# Altium schematic sheet CW341_PowerMeasure.SchDoc
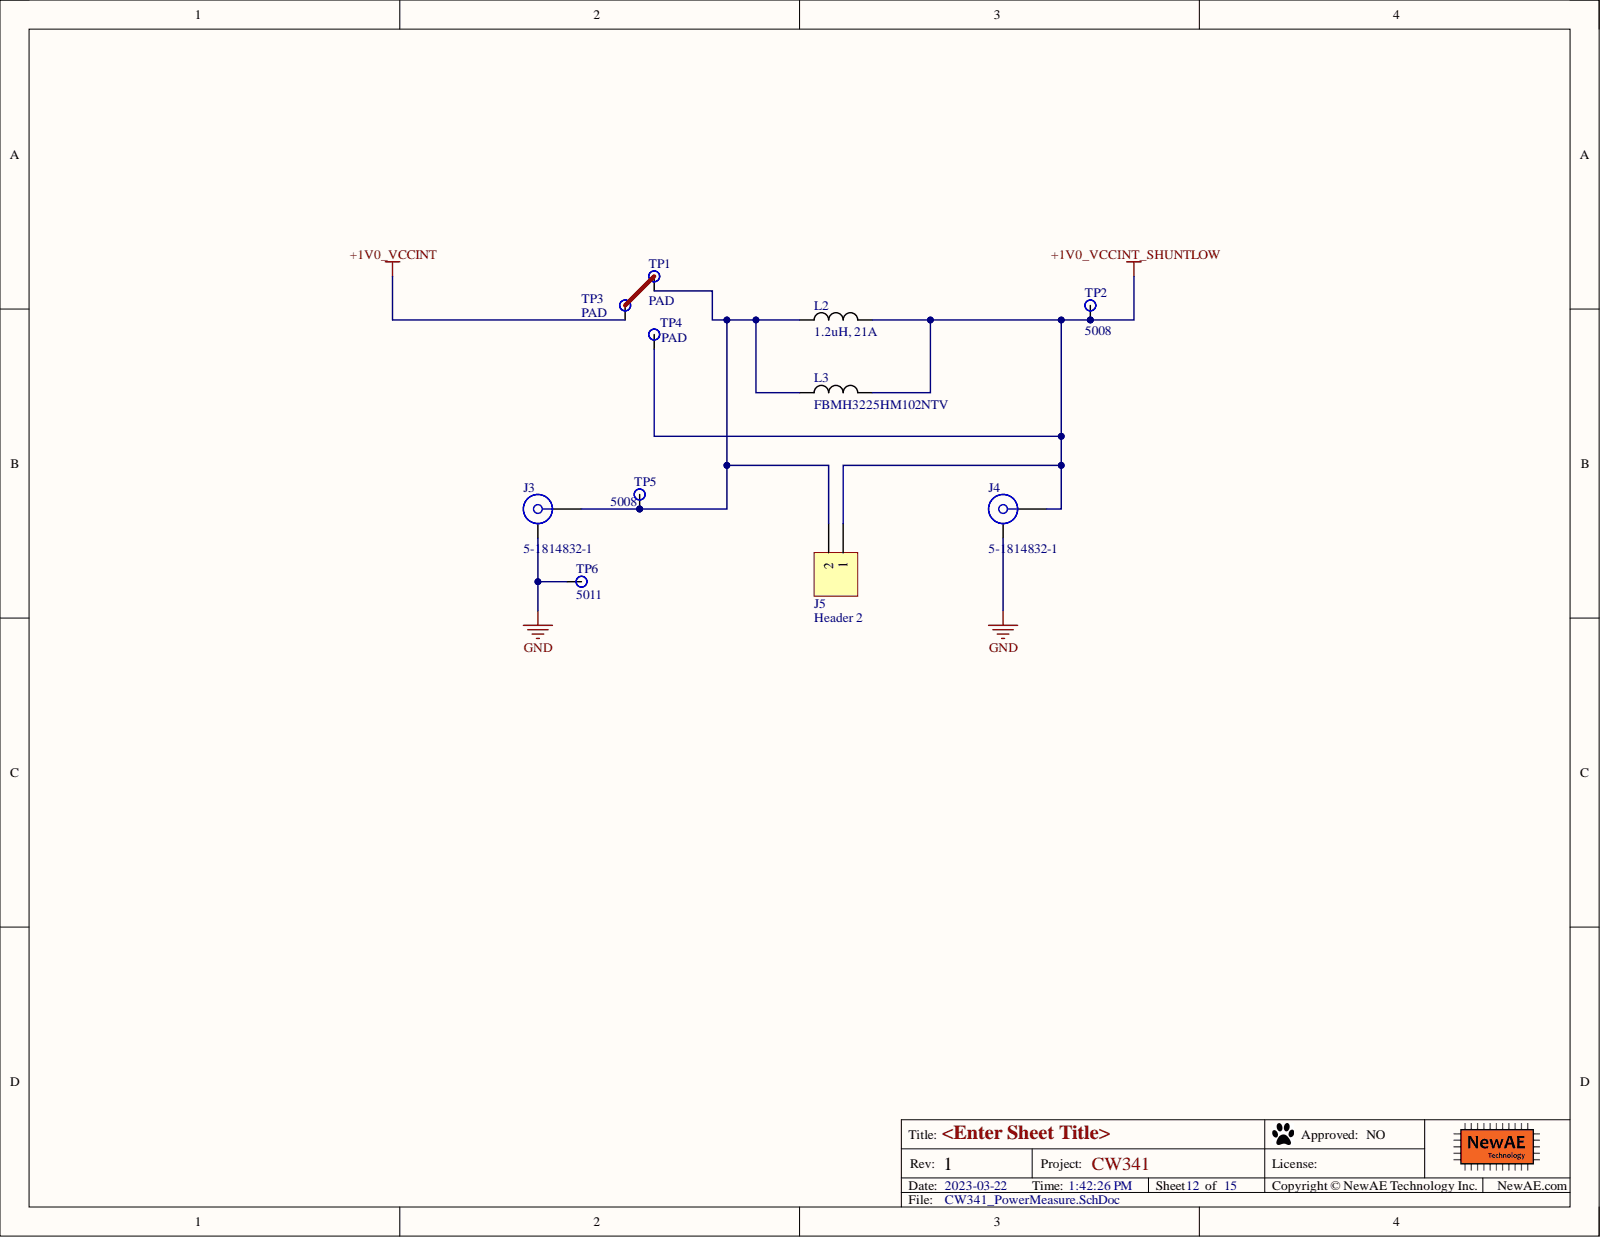
[diagram p0: CW341_PowerMeasure.SchDoc]

## Parts
J3  5-1814832-1  [SMA_VERT_1.65MMHOLE]
J4  5-1814832-1  [SMA_VERT_1.65MMHOLE]
J5  Header 2  [HDR1X2]
L2  1.2uH, 21A  [XAL7070]
L3  FBMH3225HM102NTV  [1210]
TP1  PAD  [9022-0-00-15-00-00-33-0]
TP2  5008  [KEYSTONE5008]
TP3  PAD  [9022-0-00-15-00-00-33-0]
TP4  PAD  [9022-0-00-15-00-00-33-0]
TP5  5008  [KEYSTONE5008]
TP6  5011  [KEYSTONE5011]

## Nets
+1V0_VCCINT: TP3.1
+1V0_VCCINT_SHUNTLOW: J4.1 J5.1 L2.2 L3.2 TP2.1 TP4.1
GND: J3.MH J4.MH TP6.1
NetJ3_1: J3.1 J5.2 L2.1 L3.1 TP1.1 TP5.1

## Library data of the parts
J3 J4 = 5-1814832-1: SMA, Vert; Manufacture 1: TE Connectivity; Component Group: Connector; Database Table Name: main; Component Kind: Standard; Component Type: Standard; Library Name: NewAE_AltiumLib.DBLib; Manufacture Part Number 1: 5-1814832-1; Part Number: 5-1814832-1; Pin Count: 2; Supplier 1: Digi-Key; Supplier Part Number 1: A97594-ND
J5 = Header 2: Header, 2-Pin; Component Kind: Standard; LatestRevisionDate: 17-Jul-2002; LatestRevisionNote: Re-released for DXP Platform.; Library Name: Miscellaneous Connectors.IntLib; Signal Integrity: Connector; Publisher: Altium Limited
L2 = XAL7070-122MEB: 1.2uH, 21A RMS; Manufacture 1: Coilcraft; Component Group: Inductor; Database Table Name: main; PP Material Stack: 0; Component Kind: Standard; Component Type: Standard; Library Name: NewAE_AltiumLib.DBLib; Manufacture Part Number 1: XAL7070-122MEB; Part Number: XAL7070-122MEB; Pin Count: 2; PP Rotation: 0; PP Rotation1: 0; Supplier 1: Mouser; Value: 1.2uH, 21A
L3 = FBCHIP-1K-1210: Ferrite Bead, 1K@100MHz, 2A, 1210, 100mOhm DC, AEC-Q200; Manufacture 1: Taiyo Yuden; Case-Metric: 3225; Component Group: Inductor; Database Table Name: main; PP Material Stack: 0; Case-EIA: 1210; Component Kind: Standard; Component Type: Standard; Library Name: NewAE_AltiumLib.DBLib; Manufacture Part Number 1: FBMH3225HM102NTV; Mounting Technology: SMT; Part Number: FBCHIP-1K-1210; Pin Count: 2; PP Rotation: 0; RoHS: Yes; Supplier 1: Digi-Key; Supplier Part Number 1: 587-3835-1-ND; Temperature Range: -40C to 125C; Value: 1k@100MHz
TP1 TP3 TP4 = TESTPAD_9022-0-00-15-00-00-33-0: Manufacture 1: Mill-Max Manufacturing Corp.; Component Group: Test Point; Database Table Name: main; Component Kind: Standard; Component Type: Standard; Description: PAD; Library Name: NewAE_AltiumLib.DBLib; Manufacture Part Number 1: 9022-0-00-15-00-00-33-0; Mounting Technology: Through-hole; Part Number: TESTPAD_9022-0-00-15-00-00-33-0; Pin Count: 1; Supplier 1: Digi-Key; Supplier Part Number 1: ED90411-ND
TP2 TP5 = KEYSTONE_5008: TEST POINT PC COMPACT .063"D ORG; Database Table Name: main; Component Group: Test Point; Component Kind: Standard; Component Type: Standard; Library Name: NewAE_AltiumLib.DBLib; Mounting Technology: Through-hole; Part Number: KEYSTONE_5008; Pin Count: 1; Supplier 1: Digi-Key; Supplier Part Number 1: 36-5008-ND
TP6 = KEYSTONE_5011: TEST POINT PC MULTI PURPOSE BLK; Database Table Name: main; Component Group: Test Point; Component Kind: Standard; Component Type: Standard; Library Name: NewAE_AltiumLib.DBLib; Mounting Technology: Through-hole; Part Number: KEYSTONE_5011; Pin Count: 1; Supplier 1: Digi-Key; Supplier Part Number 1: 36-5011-ND
